# Altium schematic sheet POWER.SchDoc
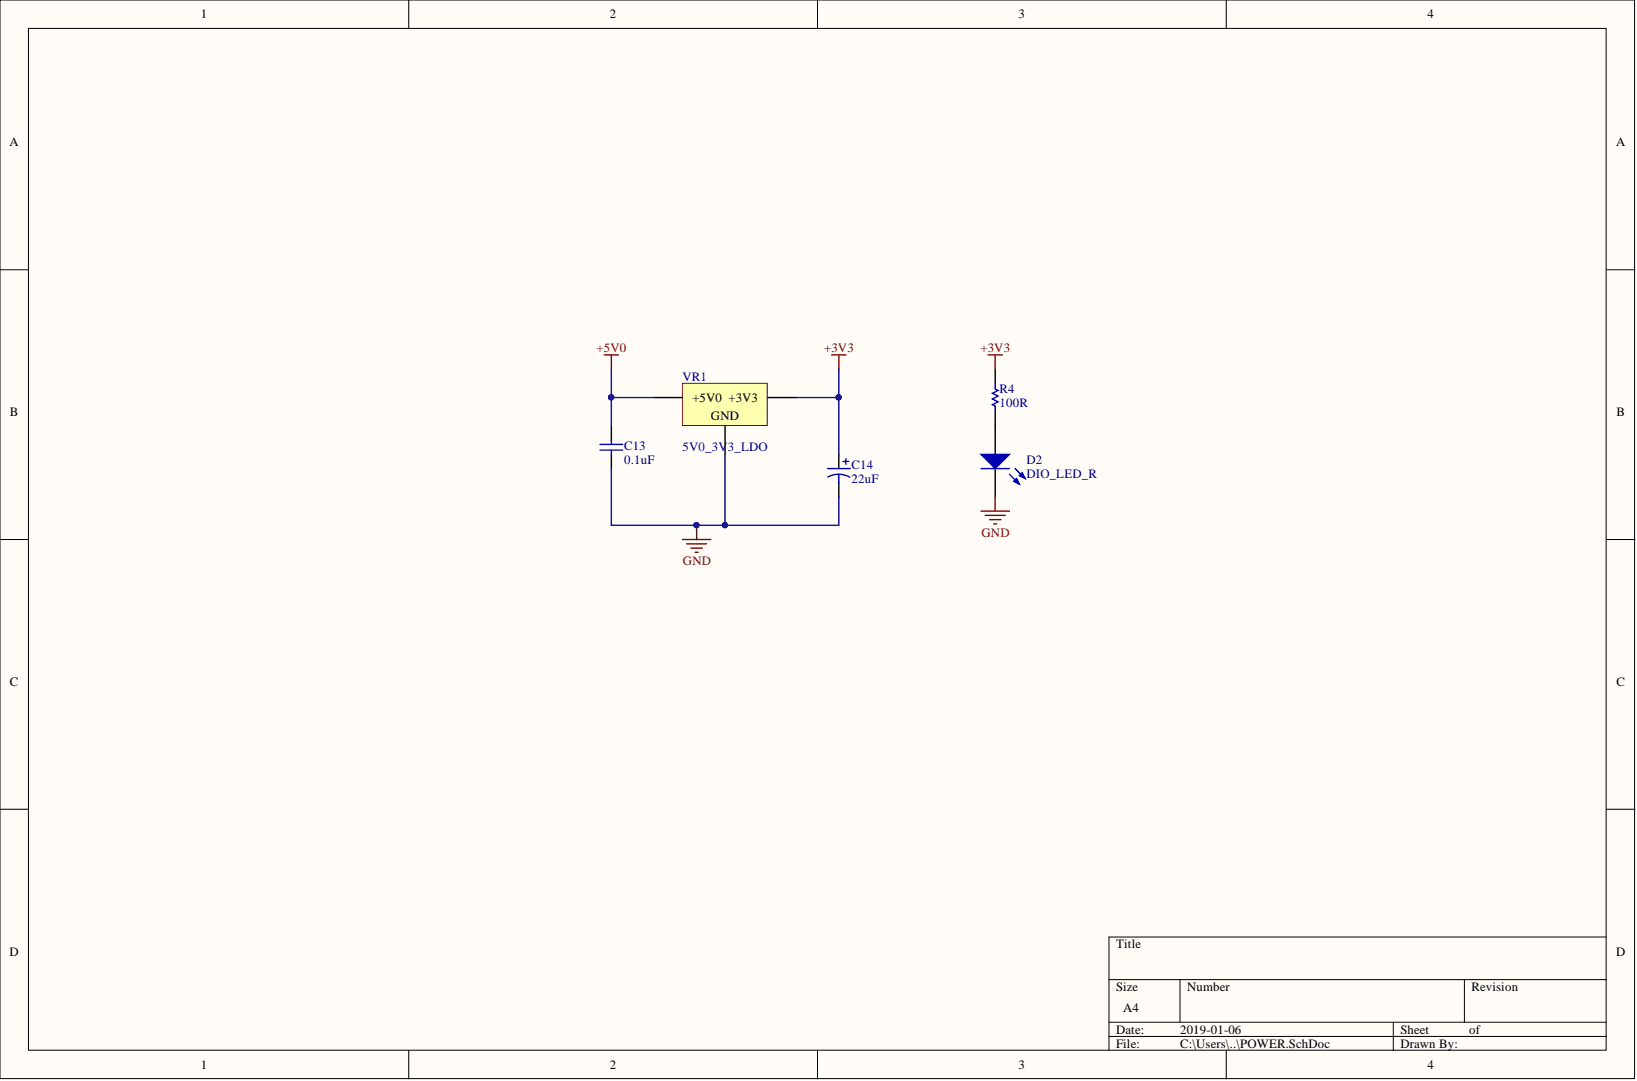
[diagram p0: POWER.SchDoc]

## Parts
C13  value 0.1uF  [CAPC0603(1608)55_N]  (hidden comment: CAP_0.1uF_16V_0603)
C14  value 22uF  [CAPR-2/4h6.8]  (hidden comment: CAP_22uF_POL)
D2  DIO_LED_R  [LED_0805]
R4  value 100R  [RESC0603(1608)_N]  (hidden comment: RES_100R_0603)
VR1  5V0_3V3_LDO  [VOLTAGE_REGULATOR]

## Nets
+3V3: C14.1 R4.2 VR1.2
+5V0: C13.2 VR1.3
GND: C13.1 C14.2 D2.2 VR1.1
NetD2_1: D2.1 R4.1

## Library data of the parts
C13 = CAP_0.1uF_16V_0603: CAP CER 0.1UF 16V X7R 0603; Manufacturer: Yageo; Part Number: CC0603KRX7R7BB104; Component Kind: Standard; Dimensions: 0.063" L x 0.031" W (1.60mm x 0.80mm); Package: 0603 (1608 Metric); Signal Integrity: Cap; Simulation: CAP; Temperature Coefficient: X7R; Voltage Rating: 16V; Library Name: UWRT_Schematic_Library_2019.SchLib
C14 = CAP_22uF_POL: CAP ALUM 22UF 20% 50V RADIAL; Manufacturer: Wurth Electronics Inc.; Part Number: 860020672011; Component Kind: Standard; Signal Integrity: Cap Pol; Simulation: CAP; Tolerance: 20%; Voltage Rating: 50V; Library Name: UWRT_Schematic_Library_2019.SchLib
D2 = DIO_LED_R: LED RED DIFFUSED 0805 SMD; Manufacturer: OSRAM; Part Number: LS R976-NR-1; Forward Voltage Drop: 2V; Component Kind: Standard; Package: 0805 (2012 Metric); Simulation: LED1; Library Name: UWRT_Schematic_Library_2019.SchLib
R4 = RES_100R_0603: RES SMD 100 OHM 1% 1/10W 0603; Manufacturer: Yageo; Part Number (MPN): RC0603FR-07100RL; Component Kind: Standard; Dimensions: 0.063" L x 0.031" W (1.60mm x 0.80mm); Package: 0603 (1608 Metric); Signal Integrity: Res; Simulation: RESISTOR; Tolerance: 1%; Library Name: UWRT_Schematic_Library_2019.SchLib
VR1 = 5V0_3V3_LDO: IC REG LINEAR 3.3V 1A DPAK; Manufacturer: ON Semiconductor; Part Number: NCP1117DT33T5G; Component Kind: Standard; Current Output: 1A; Library Name: UWRT_Schematic_Library_2019.SchLib
